# Altium schematic sheet POWER.SchDoc
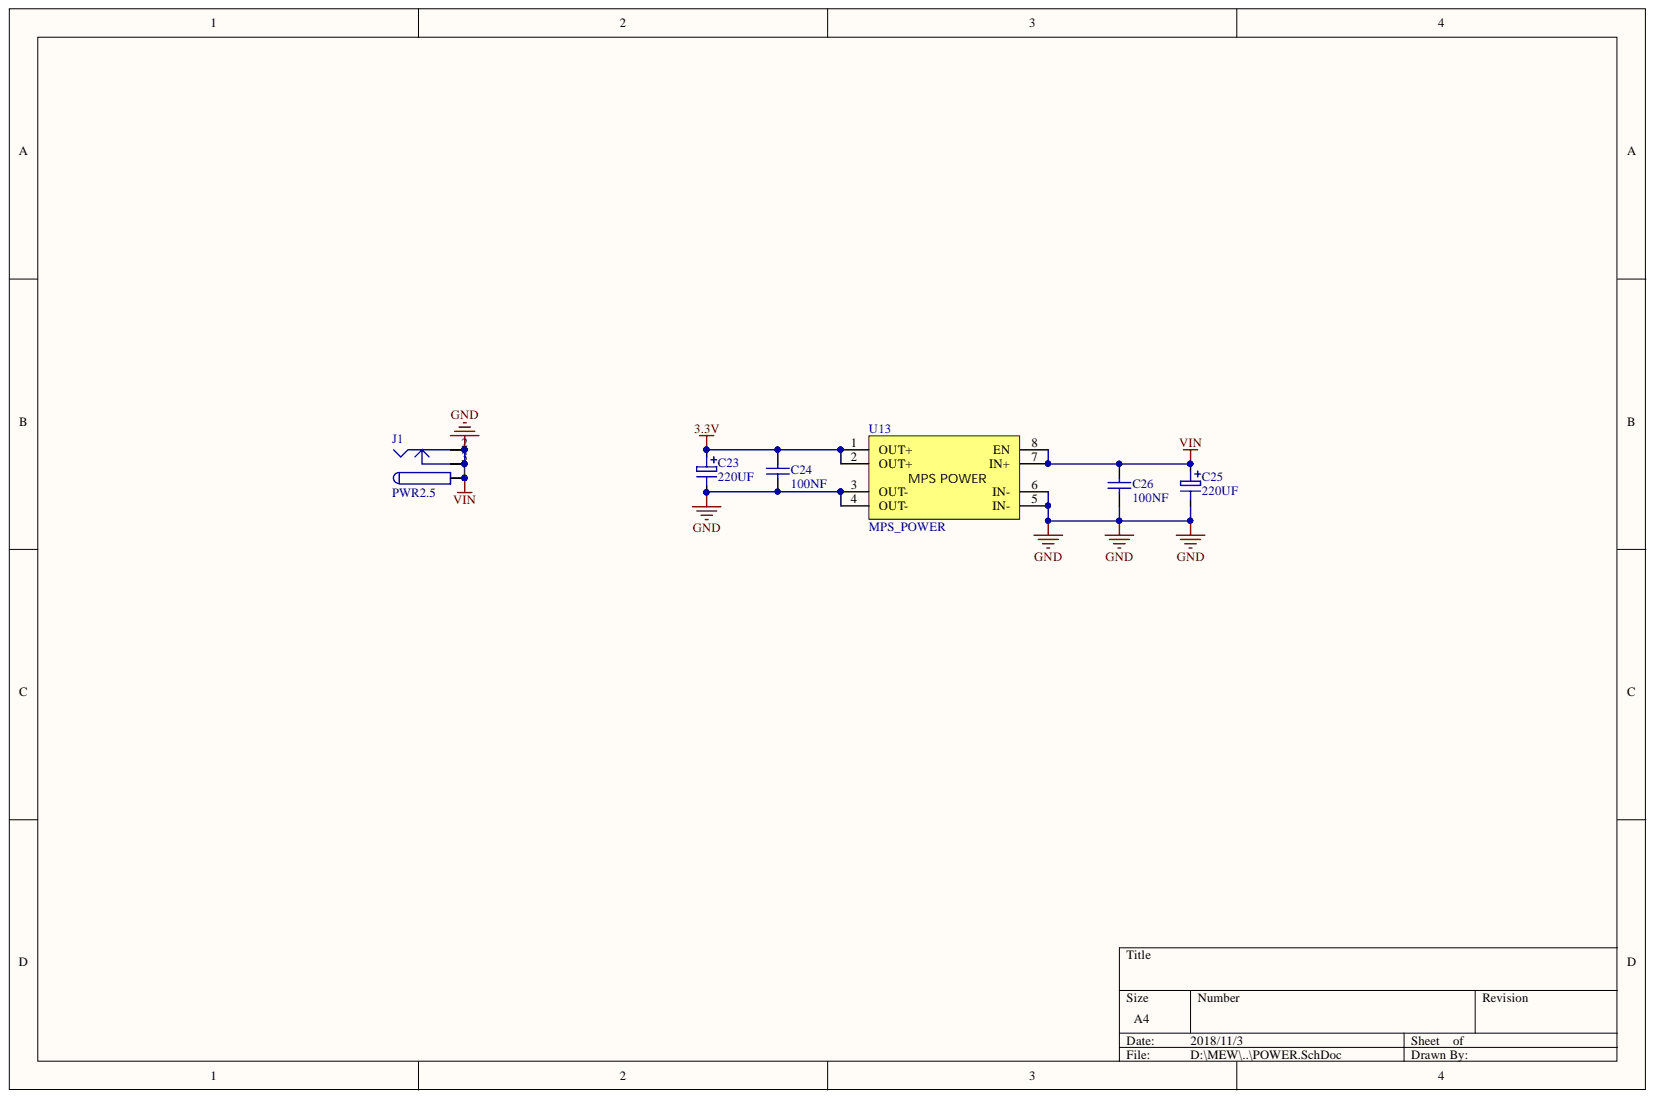
[diagram p0: POWER.SchDoc]

## Parts
C23  220UF  [CAP-SMD-F6.3]
C24  100NF  [RC0603]
C25  220UF  [CAP-SMD-F6.3]
C26  100NF  [RC0603]
J1  PWR2.5  [KLD-0202]
U13  MPS_POWER  [MPS1584EN]

## Nets
3.3V: C23.1 C24.1 U13.1(OUT+) U13.2(OUT+)
GND: C23.2 C24.2 C25.2 C26.2 J1.2 J1.2A J1.2B J1.3 J1.3A J1.3B U13.3(OUT-) U13.4(OUT-) U13.5(IN-) U13.6(IN-)
VIN: C25.1 C26.1 J1.1 J1.1A J1.1B U13.7(IN+) U13.8(EN)

## Library data of the parts
C23 C25 = CAP-aluminum: Component Kind: Standard; Library Name: HFNADLIB.SchLib
C24 C26 = CAP-ceramic: Component Kind: Standard; Library Name: HFNADLIB.SchLib
J1 = PWR2.5: Low Voltage Power Supply Connector; Component Kind: Standard; ComponentLink1Description: Manufacturer Link; ComponentLink1URL: http://domino.kycon.com/internet/Products.html; DatasheetDocument: 09-May-2002; Library Name: Miscellaneous Connectors.IntLib; Published: 17-Dec-2003; Signal Integrity: Connector; Publisher: Altium Limited
U13 = MPS_POWER: Component Kind: Standard; Library Name: HFNADLIB.SchLib
